# Altium schematic sheet yezi52_1.4.SchDoc(yezi52_1.4)
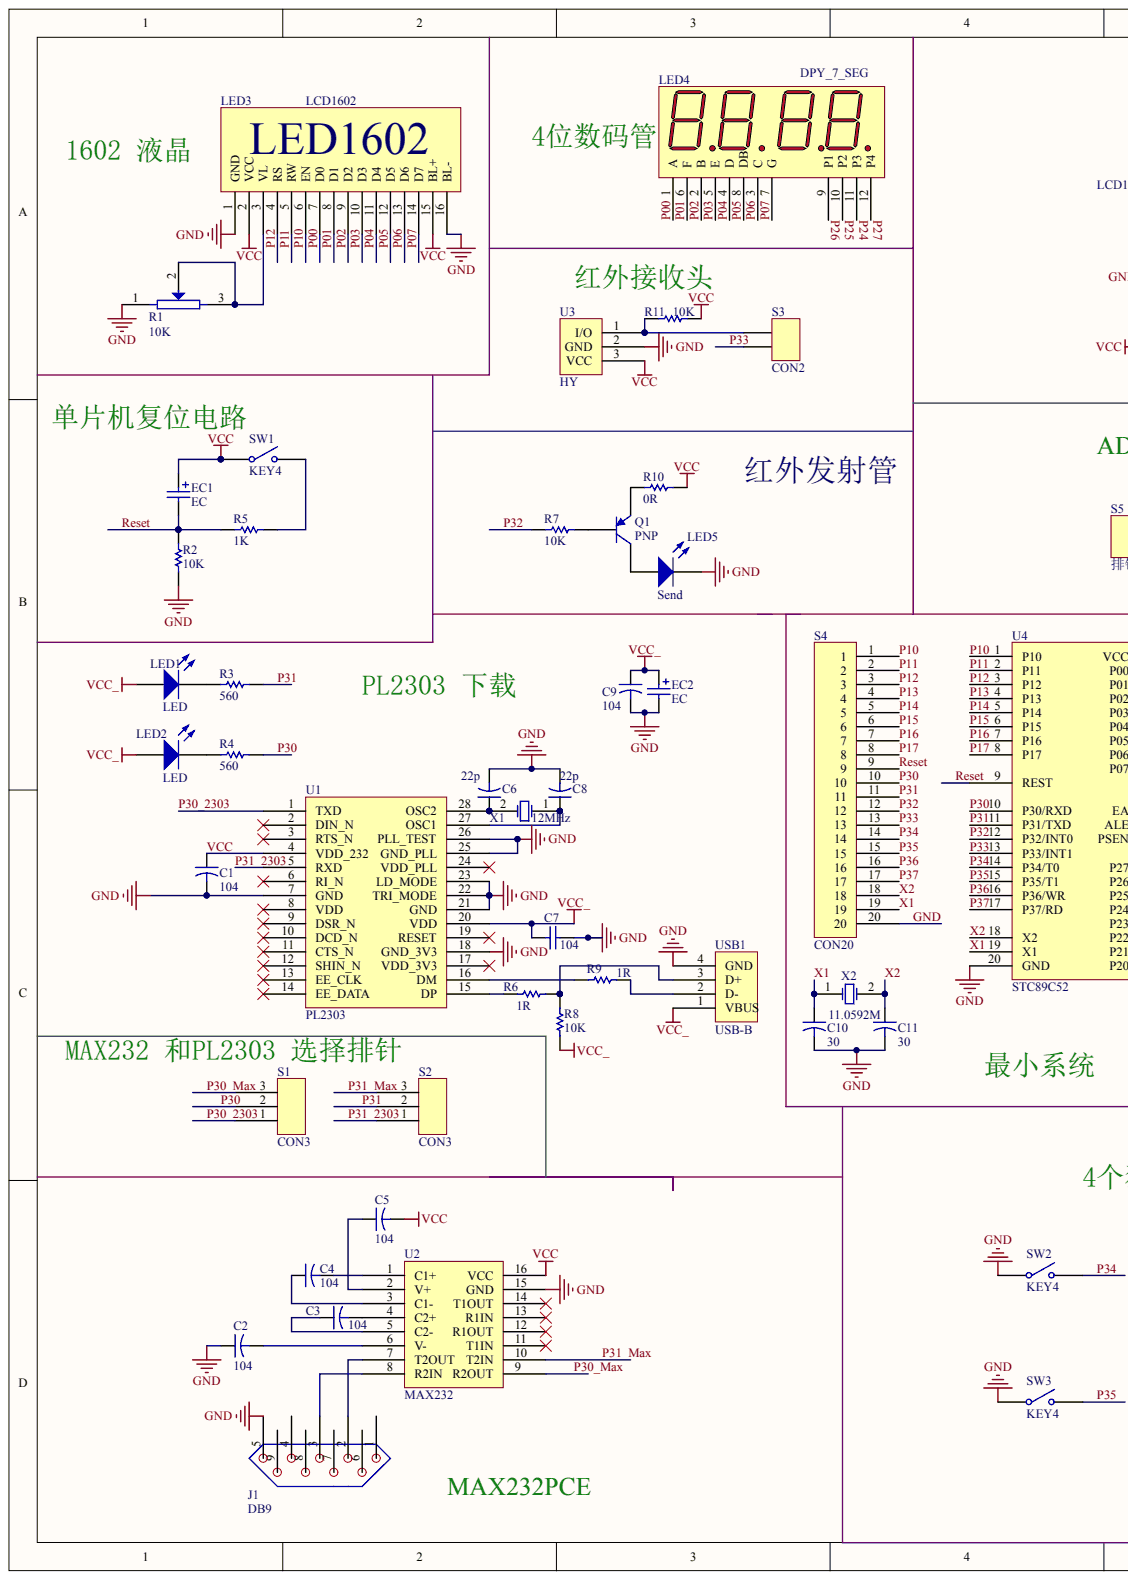
[diagram p0: yezi52_1.4.SchDoc, left]
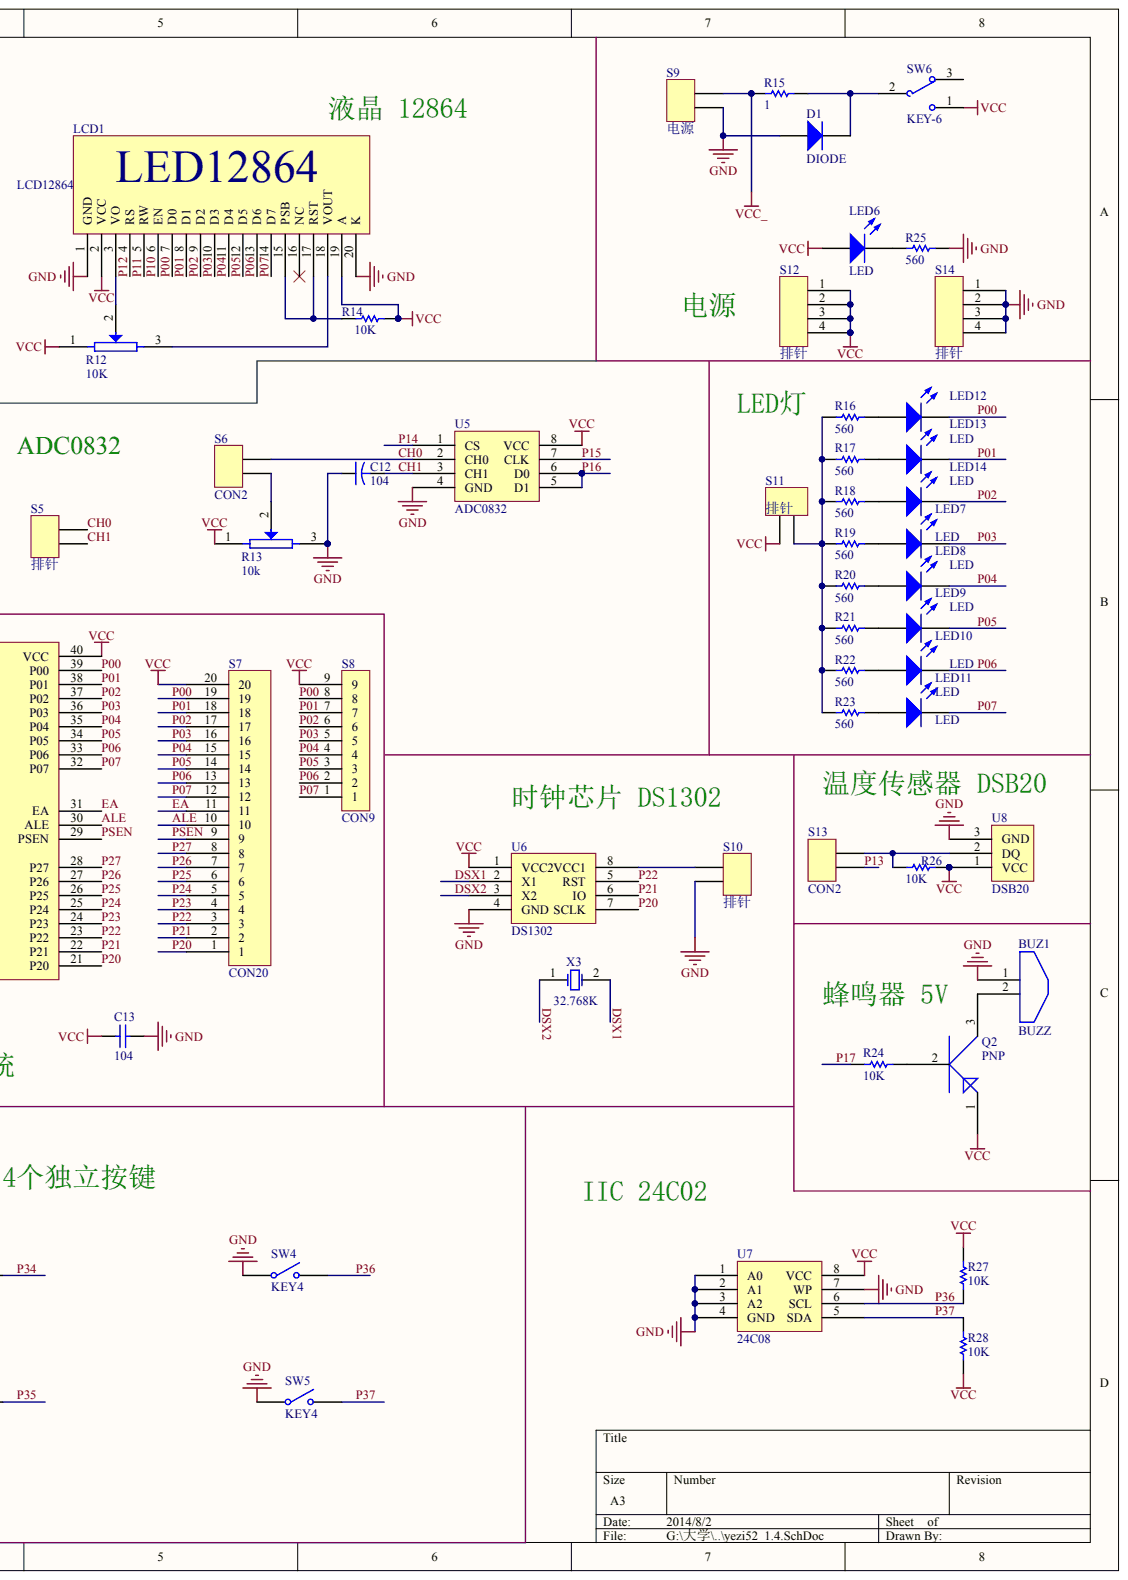
[diagram p1: yezi52_1.4.SchDoc, right]

## Parts
BUZ1  BUZZ
C1  104
C2  104
C3  104
C4  104
C5  104
C6  22p
C7  104
C8  22p
C9  104
C10  30
C11  30
C12  104
C13  104
D1  DIODE
EC1  EC
EC2  EC
J1  DB9
LCD1  LCD12864
LED1  LED
LED2  LED
LED3  LCD1602
LED4  DPY_7_SEG
LED5  Send
LED6  LED
LED7  LED
LED8  LED
LED9  LED
LED10  LED
LED11  LED
LED12  LED
LED13  LED
LED14  LED
Q1  PNP
Q2  PNP
R1  10K
R2  10K
R3  560
R4  560
R5  1K
R6  1R
R7  10K
R8  10K
R9  1R
R10  0R
R11  10K
R12  10K
R13  10k
R14  10K
R15  1
R16  560
R17  560
R18  560
R19  560
R20  560
R21  560
R22  560
R23  560
R24  10K
R25  560
R26  10K
R27  10K
R28  10K
S1  CON3
S2  CON3
S3  CON2
S4  CON20
S5  排针
S6  CON2
S7  CON20
S8  CON9
S9  电源
S10  排针
S11  排针
S12  排针
S13  CON2
S14  排针
SW1  KEY4
SW2  KEY4
SW3  KEY4
SW4  KEY4
SW5  KEY4
SW6  KEY-6
U1  PL2303
U2  MAX232
U3  HY
U4  STC89C52
U5  ADC0832
U6  DS1302
U7  24C08
U8  DSB20
USB1  USB-B
X1  12MHz
X2  11.0592M
X3  32.768K

## Nets
VCC: C5.2 C13.1 EC1.1 LCD1.2(VCC) LCD1.19(A) LED3.2(VCC) LED3.15(BL+) LED6.1 Q2.1 R10.2 R11.2 R12.1 R13.1 R14.2 R26.1 R27.2 R28.1 S7.20 S8.9 S11.2 S12.1 S12.2 S12.3 S12.4 SW1.1 SW6.1 U2.16(VCC) U3.3 U4.40(VCC) U5.8(VCC) U6.1(VCC2) U7.8(VCC) U8.1
ALE: S7.10 U4.30(ALE)
CH0: S5.1 S6.1 U5.2(CH0)
CH1: C12.2 S5.2 U5.3(CH1)
DSX1: U6.2(X1) X3.2
DSX2: U6.3(X2) X3.1
EA: S7.11 U4.31(EA)
GND: BUZ1.1 C1.2 C2.1 C6.2 C7.2 C8.2 C9.2 C10.2 C11.2 C12.1 C13.2 D1.1 EC2.2 J1.5 LCD1.1(GND) LCD1.20(K) LED3.1(GND) LED3.16(BL-) LED5.2 R1.1 R2.1 R13.3 R25.2 S4.20 S9.2 S10.1 S14.1 S14.2 S14.3 S14.4 SW2.1 SW3.1 SW4.1 SW5.1 U1.7(GND) U1.18(GND_3V3) U1.21(GND) U1.22(TRI_MODE) U1.23(LD_MODE) U1.25(GND_PLL) U1.26(PLL_TEST) U2.15(GND) U3.2 U4.20(GND) U5.4(GND) U6.4(GND) U7.1(A0) U7.2(A1) U7.3(A2) U7.4(GND) U7.7(WP) U8.3 USB1.4(GND)
P00: LCD1.7(D0) LED3.7(D0) LED4.1(A) LED12.2 S7.19 S8.8 U4.39(P00)
P01: LCD1.8(D1) LED3.8(D1) LED4.6(F) LED13.2 S7.18 S8.7 U4.38(P01)
P02: LCD1.9(D2) LED3.9(D2) LED4.2(B) LED14.2 S7.17 S8.6 U4.37(P02)
P03: LCD1.10(D3) LED3.10(D3) LED4.5(E) LED7.2 S7.16 S8.5 U4.36(P03)
P04: LCD1.11(D4) LED3.11(D4) LED4.4(D) LED8.2 S7.15 S8.4 U4.35(P04)
P05: LCD1.12(D5) LED3.12(D5) LED4.8(DB) LED9.2 S7.14 S8.3 U4.34(P05)
P06: LCD1.13(D6) LED3.13(D6) LED4.3(C) LED10.2 S7.13 S8.2 U4.33(P06)
P07: LCD1.14(D7) LED3.14(D7) LED4.7(G) LED11.2 S7.12 S8.1 U4.32(P07)
P10: LCD1.6(EN) LED3.6(EN) S4.1 U4.1(P10)
P11: LCD1.5(RW) LED3.5(RW) S4.2 U4.2(P11)
P12: LCD1.4(RS) LED3.4(RS) S4.3 U4.3(P12)
P13: S4.4 S13.2 U4.4(P13)
P14: S4.5 U4.5(P14) U5.1(CS)
P15: S4.6 U4.6(P15) U5.7(CLK)
P16: S4.7 U4.7(P16) U5.5(D1) U5.6(D0)
P17: R24.1 S4.8 U4.8(P17)
P20: S7.1 U4.21(P20) U6.7(SCLK)
P21: S7.2 U4.22(P21) U6.6(IO)
P22: S7.3 U4.23(P22) U6.5(RST)
P23: S7.4 U4.24(P23)
P24: LED4.11(P3) S7.5 U4.25(P24)
P25: LED4.10(P2) S7.6 U4.26(P25)
P26: LED4.9(P1) S7.7 U4.27(P26)
P27: LED4.12(P4) S7.8 U4.28(P27)
P30: R4.2 S1.2 S4.10 U4.10(P30/RXD)
P30_2303: S1.1 U1.1(TXD)
P30_MAX: S1.3 U2.9(R2OUT)
P31: R3.2 S2.2 S4.11 U4.11(P31/TXD)
P31_2303: S2.1 U1.5(RXD)
P31_MAX: S2.3 U2.10(T2IN)
P32: R7.1 S4.12 U4.12(P32/INT0)
P33: S3.1 S4.13 U4.13(P33/INT1)
P34: S4.14 SW2.3 U4.14(P34/T0)
P35: S4.15 SW3.3 U4.15(P35/T1)
P36: R27.1 S4.16 SW4.3 U4.16(P36/WR) U7.6(SCL)
P37: R28.2 S4.17 SW5.3 U4.17(P37/RD) U7.5(SDA)
PSEN: S7.9 U4.29(PSEN)
RESET: EC1.2 R2.2 R5.1 S4.9 U4.9(REST)
VCC_: C1.1 C7.1 C9.1 EC2.1 LED1.1 LED2.1 R8.2 R15.1 S9.1 U1.4(VDD_232) U1.20(VDD) USB1.1(VBUS)
X1: C10.1 S4.19 U4.19(X1) X2.1
X2: C11.1 S4.18 U4.18(X2) X2.2
NetBUZ1_2: BUZ1.2 Q2.3
NetC2_2: C2.2 U2.6(V-)
NetC3_1: C3.1 U2.5(C2-)
NetC3_2: C3.2 U2.4(C2+)
NetC4_1: C4.1 U2.3(C1-)
NetC4_2: C4.2 U2.1(C1+)
NetC5_1: C5.1 U2.2(V+)
NetC6_1: C6.1 U1.28(OSC2) X1.2
NetC8_1: C8.1 U1.27(OSC1) X1.1
NetD1_2: D1.2 R15.2 SW6.2
NetJ1_2: J1.2 U2.7(T2OUT)
NetJ1_3: J1.3 U2.8(R2IN)
NetLCD1_3: LCD1.3(VO) R12.2
NetLCD1_15: LCD1.15(PSB) LCD1.17(RST) R14.1
NetLCD1_18: LCD1.18(VOUT) R12.3
NetLED1_2: LED1.2 R3.1
NetLED2_2: LED2.2 R4.1
NetLED3_3: LED3.3(VL) R1.2 R1.3
NetLED5_1: LED5.1 Q1.3
NetLED6_2: LED6.2 R25.1
NetLED7_1: LED7.1 R19.2
NetLED8_1: LED8.1 R20.2
NetLED9_1: LED9.1 R21.2
NetLED10_1: LED10.1 R22.2
NetLED11_1: LED11.1 R23.2
NetLED12_1: LED12.1 R16.2
NetLED13_1: LED13.1 R17.2
NetLED14_1: LED14.1 R18.2
NetQ1_1: Q1.1 R10.1
NetQ1_2: Q1.2 R7.2
NetQ2_2: Q2.2 R24.2
NetR5_2: R5.2 SW1.3
NetR6_1: R6.1 U1.15(DP)
NetR6_2: R6.2 R8.1 USB1.3(D+)
NetR9_1: R9.1 U1.16(DM)
NetR9_2: R9.2 USB1.2(D-)
NetR11_1: R11.1 S3.2 U3.1
NetR13_2: R13.2 S6.2
NetR16_1: R16.1 R17.1 R18.1 R19.1 R20.1 R21.1 R22.1 R23.1 S11.1
NetR26_2: R26.2 S13.1 U8.2
NetS10_2: S10.2 U6.8(VCC1)

Not connected: J1 1 4 6 7 8 9; LCD1 16(NC); SW6 3; U1 2(DIN_N) 3(RTS_N) 6(RI_N) 8(VDD) 9(DSR_N) 10(DCD_N) 11(CTS_N) 12(SHIN_N) 13(EE_CLK) 14(EE_DATA) 17(VDD_3V3) 19(RESET) 24(VDD_PLL); U2 11(T1IN) 12(R1OUT) 13(R1IN) 14(T1OUT)
